# Altium schematic sheet UVMeter.SchDoc
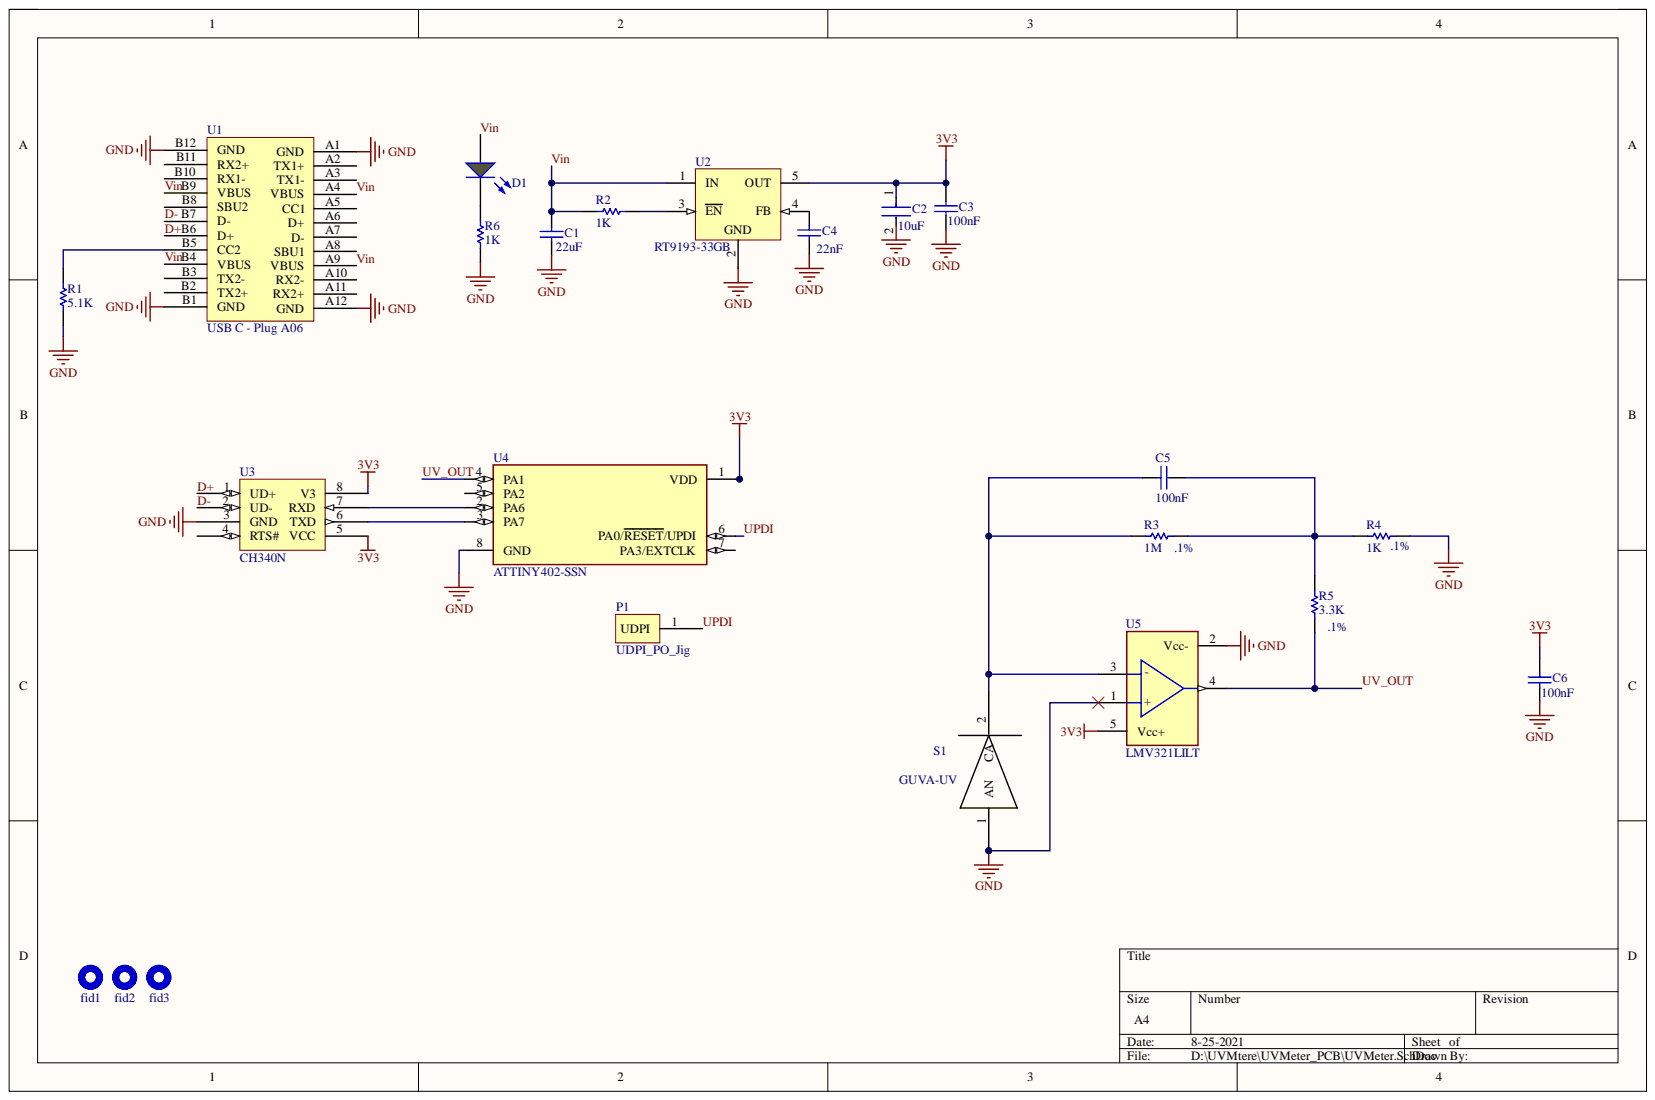
[diagram p0: UVMeter.SchDoc]

## Parts
C1  value 22uF  [CAPC2014X150X70NL20]
C2  Capacitance: 10uF  [FP-CL10-IPC_C]
C3  value 100nF  [CAPC1005X55X25ML05T10]
C4  value 22nF  [CAPC1005X55X25ML05T10]
C5  value 100nF  [CAPC1005X55X25ML05T10]
C6  value 100nF  [CAPC1005X55X25ML05T10]
D1  SML-P11MTT86  [ROHM-SML-P11MT_V]  (value not drawn)
fid1  FIDUCIAL  [FIDUCIAL]  (value not drawn)  (no BOM)
fid2  FIDUCIAL  [FIDUCIAL]  (value not drawn)  (no BOM)
fid3  FIDUCIAL  [FIDUCIAL]  (value not drawn)  (no BOM)
P1  UDPI_PO_Jig  [UDPI]
R1  value 5.1K  [RESC1005X40X25NL05T10]
R2  value 1K  [RESC1005X40X25NL05T10]
R3  value 1M  Tolerance: .1%  [RESC1005X40X25NL05T10]
R4  value 1K  Tolerance: .1%  [RESC1005X40X25NL05T10]
R5  value 3.3K  Tolerance: .1%  [RESC1005X40X25NL05T10]
R6  value 1K  [RESC1005X40X25NL05T10]
S1  GUVA-UV  [GUVA-S12SD]
U1  USB C - Plug A06  [USB C - Plug A06]
U2  RT9193-33GB  [DBV0005A_M]
U3  CH340N  [CH340N]
U4  ATTINY402-SSN  [FP-C04-057-SWB-IPC_B]
U5  LMV321LILT  [FP-SOT23-5-GAMS1812131437-IPC_C]

## Nets
3V3: C2.1 C3.2 C6.2 U2.5(OUT) U3.5(VCC) U3.8(V3) U4.1(VDD) U5.5(Vcc+)
GND: C1.2 C2.2 C3.1 C4.1 C6.1 R1.1 R4.1 R6.1 S1.1 U1.A1(GND) U1.A12(GND) U1.B1(GND) U1.B12(GND) U2.2 U3.3(GND) U4.8(GND) U5.1(+) U5.2(Vcc-)
D+: U1.B6(D+) U3.1(UD+)
D-: U1.B7(D-) U3.2(UD-)
UPDI: P1.1 U4.6(PA0/RESET/UPDI)
UV_OUT: R5.2 U4.4(PA1) U5.4
Vin: C1.1 D1.1 R2.2 U1.A4(VBUS) U1.A9(VBUS) U1.B4(VBUS) U1.B9(VBUS) U2.1(IN)
NetC4_2: C4.2 U2.4(FB)
NetC5_1: C5.1 R3.1 R4.2 R5.1
NetC5_2: C5.2 R3.2 S1.2 U5.3(-)
NetD1_2: D1.2 R6.2
NetR1_2: R1.2 U1.B5(CC2)
NetR2_1: R2.1 U2.3(EN)
NetU3_6: U3.6(TXD) U4.3(PA7)
NetU3_7: U3.7(RXD) U4.2(PA6)

Not connected: U1 A2(TX1+) A3(TX1-) A5(CC1) A6(D+) A7(D-) A8(SBU1) A10(RX2-) A11(RX2+) B2(TX2+) B3(TX2-) B8(SBU2) B10(RX1-) B11(RX2+); U3 4(RTS#); U4 5(PA2) 7(PA3/EXTCLK)

## Library data of the parts
C1 = CMP-2007-00031-1: CAP TANT 22UF 20% 6.3V 0805; Manufacturer: AVX Interconnect / Elco; Part Number: TACR226M006XTA; MK: TMCP0J226MTRF; Component Kind: Standard; Library Name: Altium Content Vault
C2 = CMP-13271-001938-1: CAP CER 10UF 6.3V X5R 0603; Tolerance: 20%; Case Code (Imperial): 0603; Case Code (Metric): 1608; Case/Package: 0603; Component Kind: Standard; Contact Plating: Tin; Depth: 0.8mm; Dielectric: X5R; Height: 0.8mm; Length: 1.6mm; Library Name: Altium Content Vault; Max Operating Temperature: 85°C; Min Operating Temperature: -55°C; Mount: Surface Mount; Package Quantity: 1; Packaging: Tape and Reel; Radiation Hardening: No; Thickness: 0.035inch; Voltage: 6.3V; Voltage Rating: 6.3V; Width: 0.031inch
C3 C5 C6 = CMP-2008-04658-1: Manufacturer: Murata; Part Number: GRM155R71H332KA01D; MK: JMK107BJ106MA-T; Component Kind: Standard; Library Name: Altium Content Vault
C4 = CMP-2008-04658-1: Manufacturer: Murata; Part Number: GRM155R71H332KA01D; MK: 0402B223K160CT; Component Kind: Standard; Library Name: Altium Content Vault
D1 = CMP-2000-07126-1: LED Green, 54 mW, 20 mA, -40 to 85 degC, 2-Pin SMD, RoHS, Tape and Reel; Manufacturer: Rohm; Forward (Drive) Current: 20mA; Forward Voltage: 1.9V; Intensity: 2.1mcd; Lens Type: Clear; Color: Green; Component Kind: Standard; Datasheet URL: https://datasheet.ciiva.com/16201/sml-p11mtt86-16201197.pdf; Datasheet Version: Rev. 2.0, 08/2012; Dimension: 1x0.6x0.2mm; Library Name: Altium Content Vault; Manufacturer URL: http://www.rohm.com/web/global/; Mounting Technology: SurfaceMount; Package Description: 2-Pin Surface Mount Device, Body 0.6 x 1 mm; Package Reference: SML-P11MT
R1 R2 R6 = CMP-1011-00001-2: Manufacturer: Yageo / Phycomp; Part Number: RC0402JR-070RL; Tolerance: 5%; Component Kind: Standard; Library Name: Altium Content Vault
R3-R5 = CMP-1011-00001-2: Manufacturer: Yageo / Phycomp; Part Number: RC0402JR-070RL; Component Kind: Standard; Library Name: Altium Content Vault
U2 = CMP-0394-00352-2: IC REG LINEAR 3.3V 300MA SOT23-5; Manufacturer: Texas Instruments; PartNumber: TPS76901HDBVT; Accuracy(%): 3; Eco-Plan: Green (RoHS & no Sb/Br); Iout(Max)(A): 0.1; Iq(Typ)(mA): 0.3; Rating: High Temp; SubFamily: Single Channel LDO; Vdo(Typ)(mV): 71; Vin(Max)(V): 10; Vin(Min)(V): 5; Vout Adj(Max)(V): 4.5; Vout Adj(Min)(V): 1.2; Code_JEDEC: MO-178; Component Kind: Standard; ComponentLink1Description: Manufacturer URL; ComponentLink1URL: http://www.ti.com/; ComponentLink2Description: Datasheet; ComponentLink2URL: http://www.ti.com/general/docs/lit/getliterature.tsp?genericPartNumber=TPS76901-HT&fileType=pdf; ComponentLink3Description: Package Specification; ComponentLink3URL: http://www.ti.com/litv/pdf/mpds018i; ComponentLink4Description: URL Link1; ComponentLink4URL: Samples URL:http://www.ti.com/product/tps76901-ht#samples; DatasheetVersion: SLVS959C; Library Name: Altium Content Vault; MK: RT9193-33GB; Mounting Technology: Surface Mount; PackageDescription: 5-Pin Plastic Small Outline, Body 1.60 Ãƒâ€” 2.90 mm, Pitch 0.95 mm; PackageReference: DBV0005A; PackageVersion: 4073253-4/K
U3 = CH340N: USB to serial chip; Component Kind: Standard; Package: SOP8; Library Name: Cheetah_Altium_Library.IntLib
U4 = CMP-06276-000169-1: 20MHZ 4KB SOIC8 IND 105C GREEN T; Component Kind: Standard; Library Name: Altium Content Vault; RoHS Compliant: Yes
U5 = CMP-12131-000010-1: IC OPAMP GP 1 CIRCUIT SOT23-5; Manufacturer: STMicroelectronics; Gain Bandwidth: 1.3MHz; Input Bias Current: 0.027uA; Input Offset Voltage: 1mV; Max Junction Temp: +150°C; Max Supply Voltage: 5.5V; Min Supply Voltage: 2.7V; Nominal Supply Current: 120-130uA; Number Of Channels: 1; Output Current Per Channel: 43mA; Voltage Gain: 90dB; Ambient Temperature Range High: +125°C; Ambient Temperature Range Low: -40°C; Automotive: No; Category: IC; Component Kind: Standard; ComponentLink2Description: Datasheet; ComponentLink2URL: https://www.st.com/resource/en/datasheet/lmv321l.pdf; Device Class L1: Integrated Circuits (ICs); Device Class L2: Linear ICs; Device Class L3: Amplifiers - Op Amps; Digikey Description: IC OPAMP GP 1 CIRCUIT SOT23-5; Height: 1.45mm; Ipc Land Pattern Name: SOT95P280X120-5; Lead Free: Yes; Library Name: Altium Content Vault; Manufacturer Part Number: LMV321LILT; Mouser Description: Operational Amplifiers - Op Amps 1.3MHz LP Gen Op-Amp 250uA at 5V 7mV 25c; Octopart: https://octopart.com/lmv321lilt-stmicroelectronics-23014004; Package: SOT23-5-GAMS1812131437CB; RoHS: TRUE; Temperature: -40°C to +125°C; Temperature Range High: +125°C; Temperature Range Low: -40°C
fid1-fid3 = FIDUCIAL: Description: FIDUCIAL; Library Name: MasterLib.SchLib
P1 = UDPI_PO_Jig: Component Kind: Standard; Library Name: Cheetah_Altium_Library.IntLib
S1 = GUVA-UV: Component Kind: Standard; Library Name: Cheetah_Altium_Library.IntLib
U1 = USB C - Plug A06: Component Kind: Standard; Library Name: Cheetah_Altium_Library.IntLib
